# Altium schematic sheet Sheet1.SchDoc
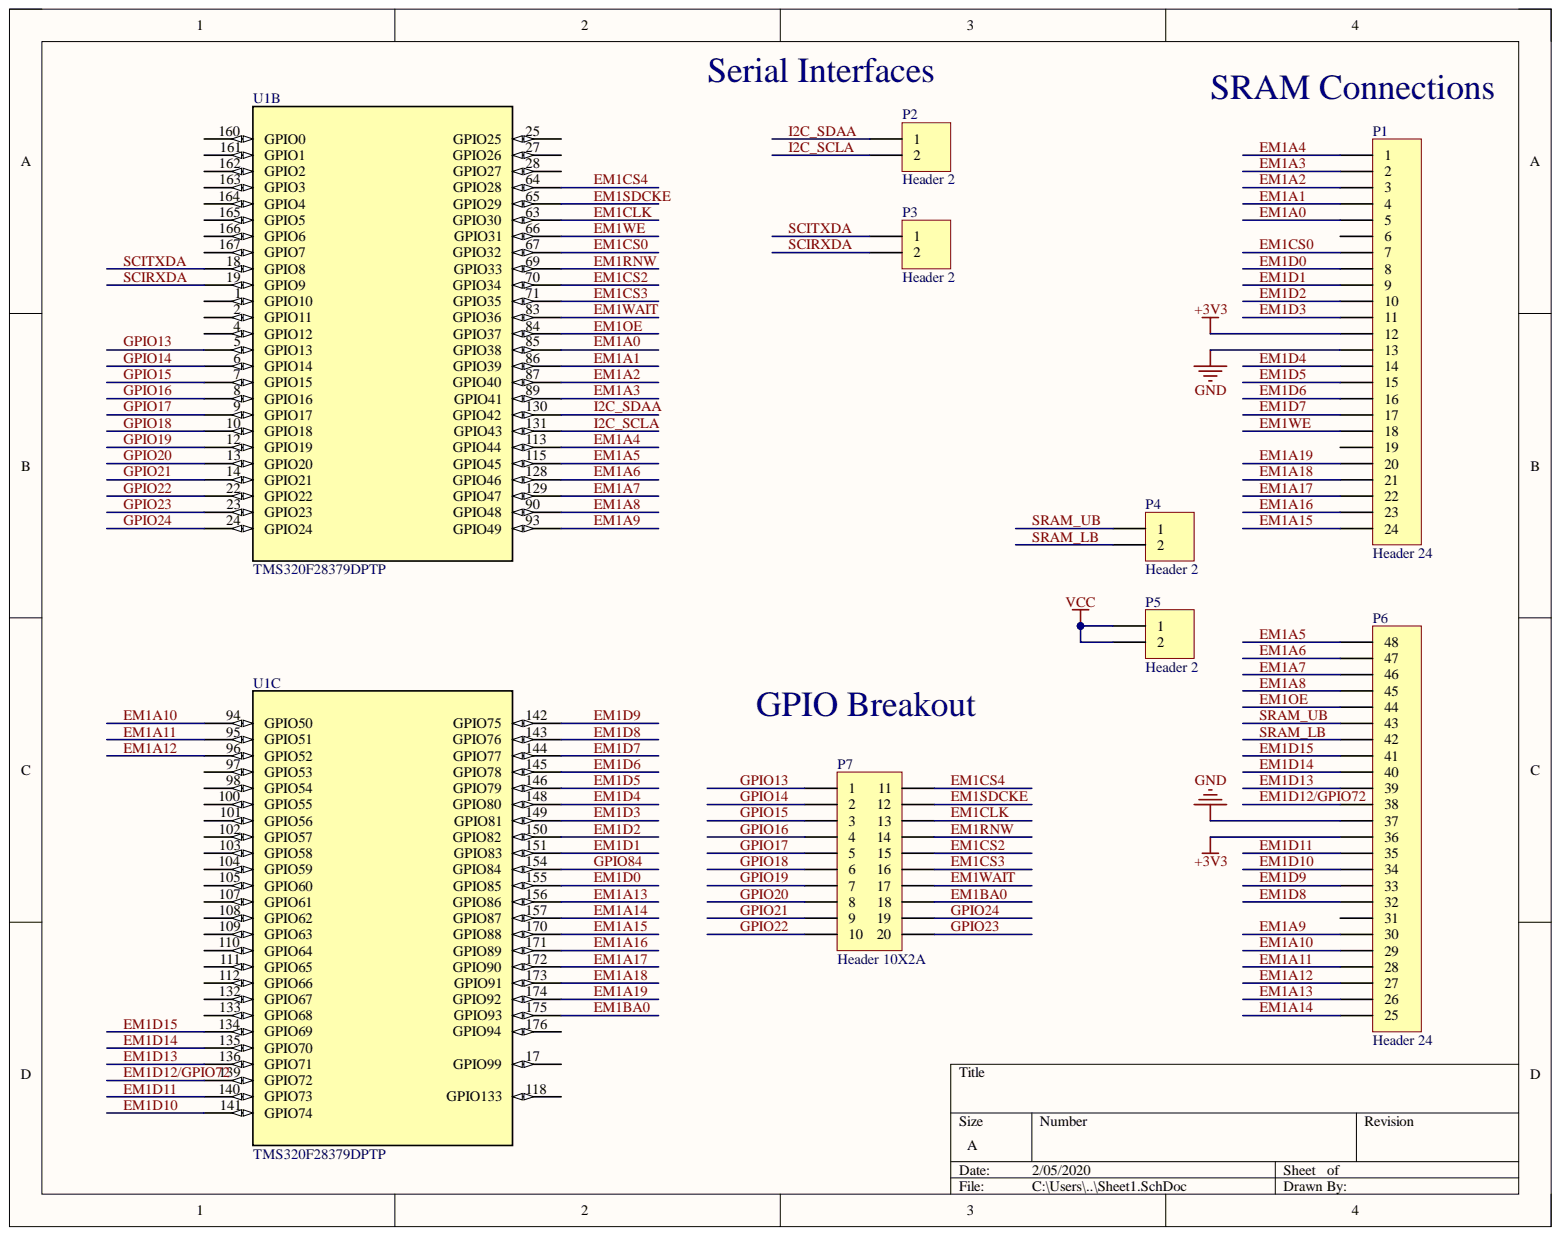
[diagram p0: Sheet1.SchDoc]

## Parts
P1  Header 24  [HDR1X24]
P2  Header 2  [HDR1X2]
P3  Header 2  [HDR1X2]
P4  Header 2  [HDR1X2]
P5  Header 2  [HDR1X2]
P6  Header 24  [HDR1X24]
P7  Header 10X2A  [HDR2X10_CEN]
U1  TMS320F28379DPTP  [PTP0176F_L]  (parts B,C)

## Nets
+3V3: P1.12 P6.13
GND: P1.13 P6.12
VCC: P5.1 P5.2
EM1A0: P1.5 U1.85(GPIO38)
EM1A1: P1.4 U1.86(GPIO39)
EM1A2: P1.3 U1.87(GPIO40)
EM1A3: P1.2 U1.89(GPIO41)
EM1A4: P1.1 U1.113(GPIO44)
EM1A5: P6.1 U1.115(GPIO45)
EM1A6: P6.2 U1.128(GPIO46)
EM1A7: P6.3 U1.129(GPIO47)
EM1A8: P6.4 U1.90(GPIO48)
EM1A9: P6.19 U1.93(GPIO49)
EM1A10: P6.20 U1.94(GPIO50)
EM1A11: P6.21 U1.95(GPIO51)
EM1A12: P6.22 U1.96(GPIO52)
EM1A13: P6.23 U1.156(GPIO86)
EM1A14: P6.24 U1.157(GPIO87)
EM1A15: P1.24 U1.170(GPIO88)
EM1A16: P1.23 U1.171(GPIO89)
EM1A17: P1.22 U1.172(GPIO90)
EM1A18: P1.21 U1.173(GPIO91)
EM1A19: P1.20 U1.174(GPIO92)
EM1BA0: P7.18 U1.175(GPIO93)
EM1CLK: P7.13 U1.63(GPIO30)
EM1CS0: P1.7 U1.67(GPIO32)
EM1CS2: P7.15 U1.70(GPIO34)
EM1CS3: P7.16 U1.71(GPIO35)
EM1CS4: P7.11 U1.64(GPIO28)
EM1D0: P1.8 U1.155(GPIO85)
EM1D1: P1.9 U1.151(GPIO83)
EM1D2: P1.10 U1.150(GPIO82)
EM1D3: P1.11 U1.149(GPIO81)
EM1D4: P1.14 U1.148(GPIO80)
EM1D5: P1.15 U1.146(GPIO79)
EM1D6: P1.16 U1.145(GPIO78)
EM1D7: P1.17 U1.144(GPIO77)
EM1D8: P6.17 U1.143(GPIO76)
EM1D9: P6.16 U1.142(GPIO75)
EM1D10: P6.15 U1.141(GPIO74)
EM1D11: P6.14 U1.140(GPIO73)
EM1D12/GPIO72: P6.11 U1.139(GPIO72)
EM1D13: P6.10 U1.136(GPIO71)
EM1D14: P6.9 U1.135(GPIO70)
EM1D15: P6.8 U1.134(GPIO69)
EM1OE: P6.5 U1.84(GPIO37)
EM1RNW: P7.14 U1.69(GPIO33)
EM1SDCKE: P7.12 U1.65(GPIO29)
EM1WAIT: P7.17 U1.83(GPIO36)
EM1WE: P1.18 U1.66(GPIO31)
GPIO13: P7.1 U1.5(GPIO13)
GPIO14: P7.2 U1.6(GPIO14)
GPIO15: P7.3 U1.7(GPIO15)
GPIO16: P7.4 U1.8(GPIO16)
GPIO17: P7.5 U1.9(GPIO17)
GPIO18: P7.6 U1.10(GPIO18)
GPIO19: P7.7 U1.12(GPIO19)
GPIO20: P7.8 U1.13(GPIO20)
GPIO21: P7.9 U1.14(GPIO21)
GPIO22: P7.10 U1.22(GPIO22)
GPIO23: P7.20 U1.23(GPIO23)
GPIO24: P7.19 U1.24(GPIO24)
GPIO84: U1.154(GPIO84)
I2C_SCLA: P2.2 U1.131(GPIO43)
I2C_SDAA: P2.1 U1.130(GPIO42)
SCIRXDA: P3.2 U1.19(GPIO9)
SCITXDA: P3.1 U1.18(GPIO8)
SRAM_LB: P4.2 P6.7
SRAM_UB: P4.1 P6.6

Not connected: P1 6 19; P6 18; U1 1(GPIO10) 2(GPIO11) 4(GPIO12) 17(GPIO99) 25(GPIO25) 27(GPIO26) 28(GPIO27) 97(GPIO53) 98(GPIO54) 100(GPIO55) 101(GPIO56) 102(GPIO57) 103(GPIO58) 104(GPIO59) 105(GPIO60) 107(GPIO61) 108(GPIO62) 109(GPIO63) 110(GPIO64) 111(GPIO65) 112(GPIO66) 118(GPIO133) 132(GPIO67) 133(GPIO68) 160(GPIO0) 161(GPIO1) 162(GPIO2) 163(GPIO3) 164(GPIO4) 165(GPIO5) 166(GPIO6) 167(GPIO7) 176(GPIO94)

## Library data of the parts
P1 P6 = Header 24: Header, 24-Pin; Component Kind: Standard; LatestRevisionDate: 17-Jul-2002; LatestRevisionNote: Re-released for DXP Platform.; Library Name: Miscellaneous Connectors.IntLib; Signal Integrity: Connector; Publisher: Altium Limited
P2-P5 = Header 2: Header, 2-Pin; Component Kind: Standard; LatestRevisionDate: 17-Jul-2002; LatestRevisionNote: Re-released for DXP Platform.; Library Name: Miscellaneous Connectors.IntLib; Signal Integrity: Connector; Publisher: Altium Limited
P7 = Header 10X2A: Header, 10-Pin, Dual row; Component Kind: Standard; LatestRevisionDate: 17-Jul-2002; LatestRevisionNote: Re-released for DXP Platform.; Library Name: Miscellaneous Connectors.IntLib; Signal Integrity: Connector; Publisher: Altium Limited
U1 = TMS320F28379DPTP: Imported; Manufacturer: Texas Instruments; PartNumber: TMS320F28379DPTPS; Component Kind: Standard; Library Name: TMS320F28379DPTPT.SchLib; RefDes: RefDes; TYPE: TYPE; Value: Value
